# Altium schematic sheet LoRa_XCVR.SchDoc(LoRa_XCVR)
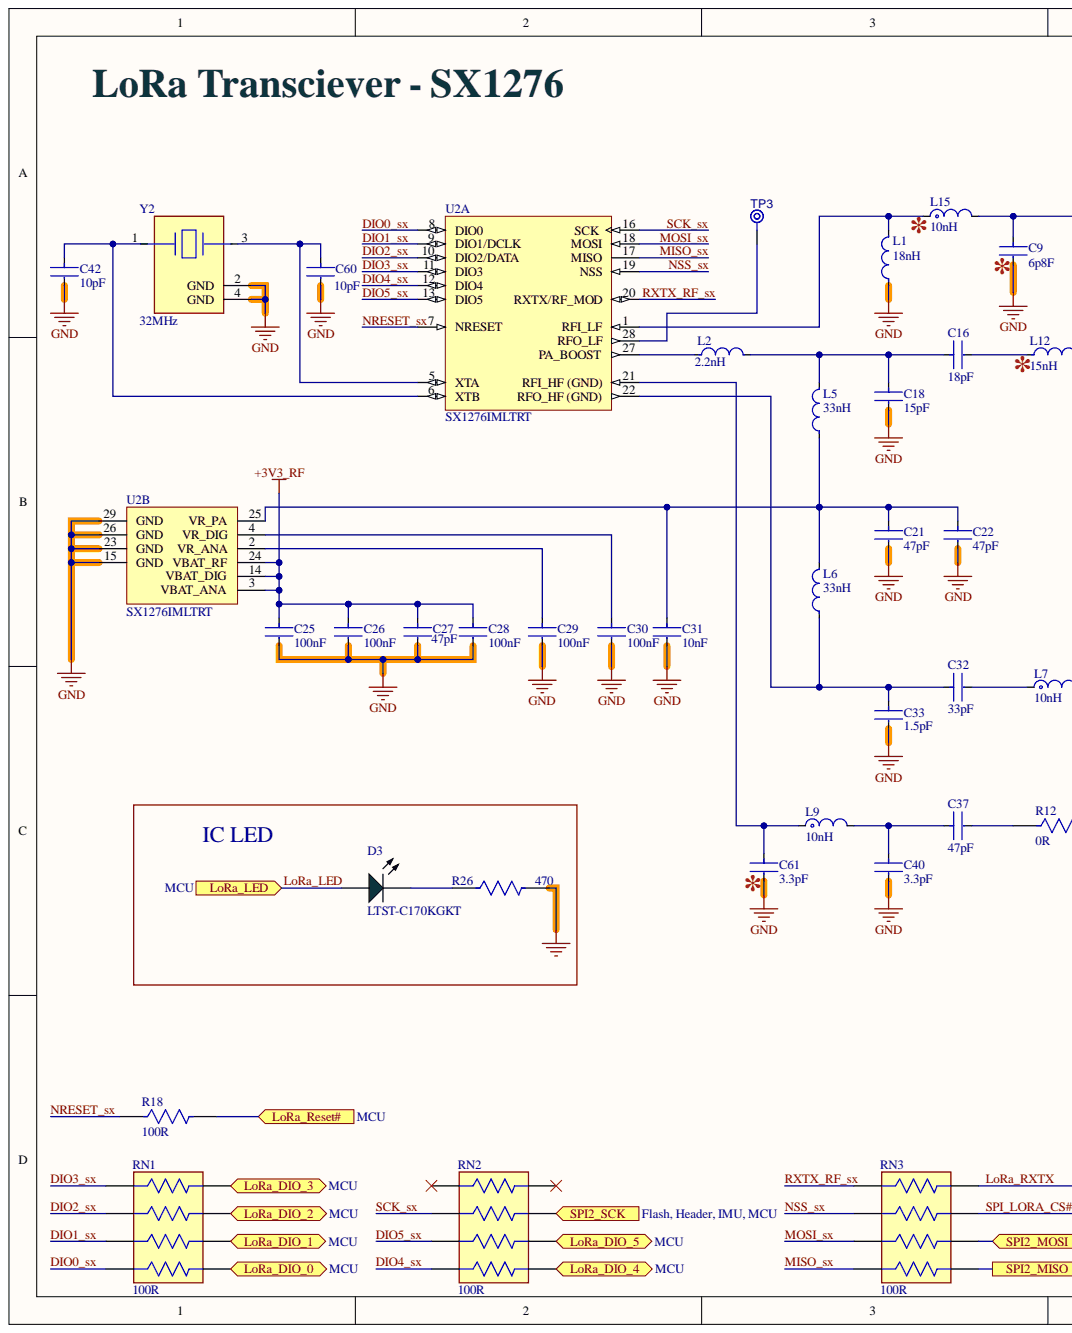
[diagram p0: LoRa_XCVR.SchDoc, left]
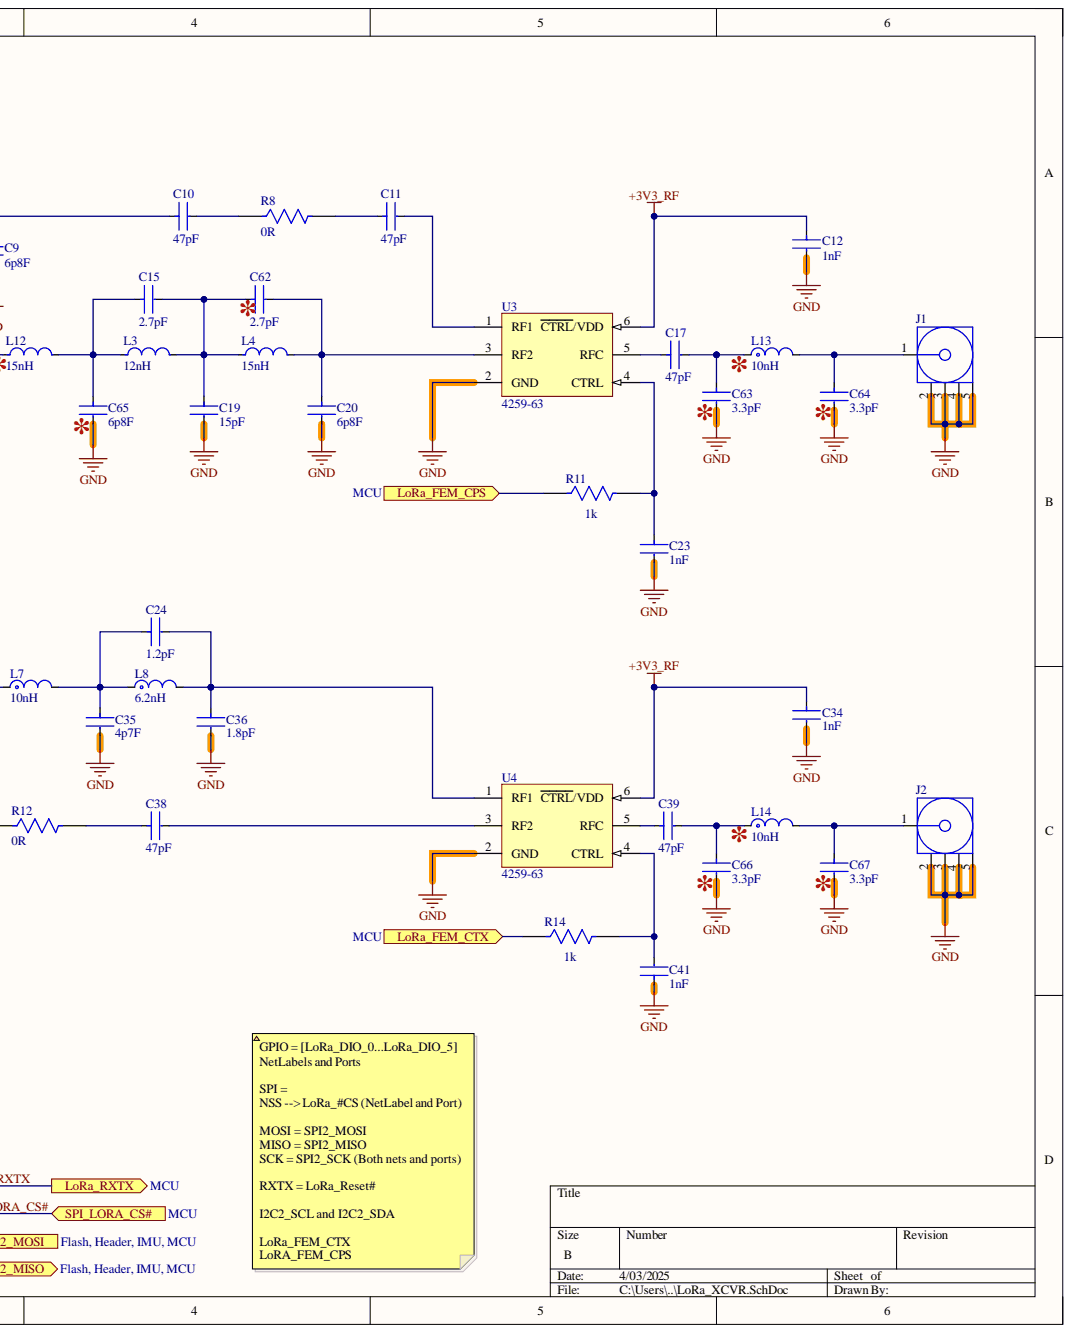
[diagram p1: LoRa_XCVR.SchDoc, right]

## Parts
C9  6p8F  [FP-GJM155-0_05-IPC_A]
C10  47pF  [FP-GJM155-0_05-MFG]
C11  47pF  [FP-GJM155-0_05-MFG]
C12  1nF  [FP-GRM155-0_05-IPC_C]
C15  2.7pF  [FP-GJM155-0_05-IPC_A]
C16  18pF  [FP-GJM155-0_05-IPC_B]
C17  47pF  [FP-GJM155-0_05-MFG]
C18  15pF  [FP-GJM155-0_05-IPC_A]
C19  15pF  [FP-GJM155-0_05-IPC_A]
C20  6p8F  [FP-GJM155-0_05-IPC_A]
C21  47pF  [FP-GJM155-0_05-MFG]
C22  47pF  [FP-GJM155-0_05-MFG]
C23  1nF  [FP-GRM155-0_05-IPC_C]
C24  1.2pF  [FP-GJM155-0_05-IPC_B]
C25  100nF  [FP-GRM155-0_05-IPC_B]
C26  100nF  [FP-GRM155-0_05-IPC_B]
C27  47pF  [FP-GJM155-0_05-MFG]
C28  100nF  [FP-GRM155-0_05-IPC_B]
C29  100nF  [FP-GRM155-0_05-IPC_B]
C30  100nF  [FP-GRM155-0_05-IPC_B]
C31  10nF  [FP-GRM155-0_05-IPC_C]
C32  33pF  [FP-GJM155-0_05-MFG]
C33  1.5pF  [FP-GJM155-0_05-IPC_C]
C34  1nF  [FP-GRM155-0_05-IPC_C]
C35  4p7F  [FP-GJM155-0_05-IPC_B]
C36  1.8pF  [FP-GJM155-0_05-IPC_B]
C37  47pF  [FP-GJM155-0_05-MFG]
C38  47pF  [FP-GJM155-0_05-MFG]
C39  47pF  [FP-GJM155-0_05-MFG]
C40  3.3pF  [FP-GJM155-0_05-IPC_C]
C41  1nF  [FP-GRM155-0_05-IPC_C]
C42  10pF  [FP-GRT155-0_05-MFG]
C60  10pF  [FP-GRT155-0_05-MFG]
C61  3.3pF  [FP-GJM155-0_05-IPC_C]
C62  2.7pF  [FP-GJM155-0_05-IPC_A]
C63  3.3pF  [FP-GJM155-0_05-IPC_C]
C64  3.3pF  [FP-GJM155-0_05-IPC_C]
C65  6p8F  [FP-GJM155-0_05-IPC_A]
C66  3.3pF  [FP-GJM155-0_05-IPC_C]
C67  3.3pF  [FP-GJM155-0_05-IPC_C]
D3  LTST-C170KGKT  [LTST-LTST-C170KGKT-2_V]
J1  142-0701-801  [CINC-142-0701-801_V]  (value not drawn)
J2  142-0701-801  [CINC-142-0701-801_V]  (value not drawn)
L1  18nH  [FP-LQW15AN_00-W0_6-0_1-MFG]
L2  2.2nH  [FP-LQW15AN_00-W0_6-0_1-MFG]
L3  12nH  [FP-LQW15AN_00-W0_6-0_1-MFG]
L4  15nH  [FP-LQW15AN_00-W0_6-0_1-MFG]
L5  33nH  [FP-LQW15AN_00-W0_5-0_1-MFG]
L6  33nH  [FP-LQW15AN_00-W0_5-0_1-MFG]
L7  10nH  [FP-LQG15HH_02-IPC_A]
L8  6.2nH  [FP-LQG15HH_02-IPC_C]
L9  10nH  [FP-LQG15HH_02-IPC_A]
L12  15nH  [FP-LQW15AN_00-W0_6-0_1-MFG]
L13  10nH  [FP-LQG15HH_02-IPC_A]
L14  10nH  [FP-LQG15HH_02-IPC_A]
L15  10nH  [FP-LQG15HH_02-IPC_A]
R8  0R  [FP-CRCW0603-C-IPC_B]
R11  1k  [FP-CRCW0402-e3-MFG]
R12  0R  [FP-CRCW0603-HPe3-MFG]
R14  1k  [FP-CRCW0402-e3-MFG]
R18  100R  [FP-CRCW0402-e3-IPC_A]
R26  470  [FP-CPF0603-MFG]
RN1  100R  [FP-EXB38V-MFG]
RN2  100R  [FP-EXB38V-MFG]
RN3  100R  [FP-EXB38V-MFG]
TP3  TPAD6R  [TP06R]  (value not drawn)  (no BOM)
U2  SX1276IMLTRT  [SMTC-QFN-28_V]  (parts A,B)
U3  4259-63  [PERE-SC-70-6_M]
U4  4259-63  [PERE-SC-70-6_M]
Y2  32MHz  [FP-ABM11-MFG]

## Ports
LoRa_DIO_0, LoRa_DIO_1, LoRa_DIO_2, LoRa_DIO_3, LoRa_DIO_4, LoRa_DIO_5, LoRa_FEM_CPS, LoRa_FEM_CTX, LoRa_LED, LoRa_Reset#, LoRa_RXTX, SPI2_MISO, SPI2_MOSI, SPI2_SCK, SPI_LORA_CS#

## Nets
+3V3_RF: C12.1 C25.1 C26.1 C27.1 C28.1 C34.1 U2.3(VBAT_ANA) U2.14(VBAT_DIG) U2.24(VBAT_RF) U3.6(CTRL/VDD) U4.6(CTRL/VDD)
GND: C9.2 C12.2 C18.2 C19.2 C20.2 C21.2 C22.2 C23.2 C25.2 C26.2 C27.2 C28.2 C29.2 C30.2 C31.2 C33.2 C34.2 C35.2 C36.2 C40.2 C41.1 C42.2 C60.2 C61.2 C63.2 C64.2 C65.2 C66.2 C67.2 J1.2 J1.3 J1.4 J1.5 J2.2 J2.3 J2.4 J2.5 L1.1 R26.2 U2.15(GND) U2.23(GND) U2.26(GND) U2.29(GND) U3.2(GND) U4.2(GND) Y2.2(GND) Y2.4(GND)
DIO0_sx: RN1.4 U2.8(DIO0)
DIO1_sx: RN1.3 U2.9(DIO1/DCLK)
DIO2_sx: RN1.2 U2.10(DIO2/DATA)
DIO3_sx: RN1.1 U2.11(DIO3)
DIO4_sx: RN2.4 U2.12(DIO4)
DIO5_sx: RN2.3 U2.13(DIO5)
LoRa_DIO_0: RN1.5 ; port LoRa_DIO_0
LoRa_DIO_1: RN1.6 ; port LoRa_DIO_1
LoRa_DIO_2: RN1.7 ; port LoRa_DIO_2
LoRa_DIO_3: RN1.8 ; port LoRa_DIO_3
LoRa_DIO_4: RN2.5 ; port LoRa_DIO_4
LoRa_DIO_5: RN2.6 ; port LoRa_DIO_5
LoRa_FEM_CPS: R11.1 ; port LoRa_FEM_CPS
LoRa_FEM_CTX: R14.1 ; port LoRa_FEM_CTX
LoRa_LED: D3.2 ; port LoRa_LED
LoRa_Reset#: R18.2 ; port LoRa_Reset#
LoRa_RXTX: RN3.8 ; port LoRa_RXTX
MISO_sx: RN3.4 U2.17(MISO)
MOSI_sx: RN3.3 U2.18(MOSI)
NRESET_sx: R18.1 U2.7(NRESET)
NSS_sx: RN3.2 U2.19(NSS)
RXTX_RF_sx: RN3.1 U2.20(RXTX/RF_MOD)
SCK_sx: RN2.2 U2.16(SCK)
SPI2_MISO: RN3.5 ; port SPI2_MISO
SPI2_MOSI: RN3.6 ; port SPI2_MOSI
SPI2_SCK: RN2.7 ; port SPI2_SCK
SPI_LORA_CS#: RN3.7 ; port SPI_LORA_CS#
NetC9_1: C9.1 C10.1 L15.2
NetC10_2: C10.2 R8.1
NetC11_1: C11.1 R8.2
NetC11_2: C11.2 U3.1(RF1)
NetC15_1: C15.1 C65.1 L3.1 L12.2
NetC15_2: C15.2 C19.1 C62.1 L3.2 L4.1
NetC16_1: C16.1 C18.1 L2.2 L5.2
NetC16_2: C16.2 L12.1
NetC17_1: C17.1 U3.5(RFC)
NetC17_2: C17.2 C63.1 L13.1
NetC20_1: C20.1 C62.2 L4.2 U3.3(RF2)
NetC21_1: C21.1 C22.1 C31.1 L5.1 L6.2 U2.25(VR_PA)
NetC23_1: C23.1 R11.2 U3.4(CTRL)
NetC24_1: C24.1 C35.1 L7.2 L8.1
NetC24_2: C24.2 C36.1 L8.2 U4.1(RF1)
NetC29_1: C29.1 U2.2(VR_ANA)
NetC30_1: C30.1 U2.4(VR_DIG)
NetC32_1: C32.1 C33.1 L6.1 U2.22(RFO_HF_(GND))
NetC32_2: C32.2 L7.1
NetC37_1: C37.1 C40.1 L9.2
NetC37_2: C37.2 R12.1
NetC38_1: C38.1 R12.2
NetC38_2: C38.2 U4.3(RF2)
NetC39_1: C39.1 U4.5(RFC)
NetC39_2: C39.2 C66.1 L14.1
NetC41_2: C41.2 R14.2 U4.4(CTRL)
NetC42_1: C42.1 U2.6(XTB) Y2.1
NetC60_1: C60.1 U2.5(XTA) Y2.3
NetC61_1: C61.1 L9.1 U2.21(RFI_HF_(GND))
NetC64_1: C64.1 J1.1 L13.2
NetC67_1: C67.1 J2.1 L14.2
NetD3_1: D3.1 R26.1
NetL1_2: L1.2 L15.1 U2.1(RFI_LF)
NetL2_1: L2.1 U2.27(PA_BOOST)
NetTP3_1: TP3.1 U2.28(RFO_LF)

Not connected: RN2 1 8

## Library data of the parts
C9 C20 C65 = CMP-2008-03772-2: Multi-Layer Ceramic Capacitor 6.8pF C0G  ±0.1pF 0402 Paper T/R; Voltage Rating: 50V; Capacitance: 6.8pF; Case Code (Imperial): 0402; Case Code (Metric): 1005; Case/Package: 0402; Component Kind: Standard; Depth: 0.5mm; Dielectric: C0G; Height: 0.5mm; Lead Free: Lead Free; Length: 1mm; Library Name: Altium Content Vault; Max Operating Temperature: 125°C; Min Operating Temperature: -55°C; Mount: Surface Mount; Package Quantity: 10000; Packaging: Tape and Reel; Radiation Hardening: No; Termination: SMD/SMT; Thickness: 0.022inch; Voltage Rating (DC): 50V; Width: 0.02inch
C10 C11 C17 C21 C22 C27 C37-C39 = CMP-06035-013708-1: Multi-Layer Ceramic Capacitor 47pF C0G  ±5% 0402 Paper T/R; Voltage Rating (DC): 50V; Capacitance: 47pF; Component Kind: Standard; Dielectric: C0G; Length: 1mm; Library Name: Altium Content Vault; Thickness: 500um; Tolerance: 5%; Width: 500um
C12 C23 C34 C41 = CMP-1034-01633-3: Chip Multilayer Ceramic Capacitors for General Purpose, 0402, 1000pF, X7R, 15%, 10%, 100V; Capacitance: 1nF; Case Code (Imperial): 0402; Case Code (Metric): 1005; Case/Package: 0402; Component Kind: Standard; Depth: 0.5mm; Dielectric: X7R; Height: 0.5mm; Lead Free: Lead Free; Length: 1mm; Library Name: Altium Content Vault; Material: Ceramic; Max Operating Temperature: 125°C; Min Operating Temperature: -55°C; Mount: Surface Mount; Package Quantity: 10000; Packaging: Tape and Reel; Radiation Hardening: No; REACH SVHC: No SVHC; Termination: SMD/SMT; Thickness: 0.022inch; Tolerance: 10%; Voltage: 100V; Voltage Rating: 100V; Voltage Rating (DC): 100V; Width: 0.5mm
C15 C62 = CMP-2008-03840-2: Multi-Layer Ceramic Capacitor 2.7pF C0G  ±0.1pF 0402 Paper T/R; Temperature Coefficient: 30ppm/°C; Voltage: 50V; Capacitance: 2.7pF; Case Code (Imperial): 0402; Case Code (Metric): 1005; Case/Package: 0402; Component Kind: Standard; Depth: 0.5mm; Dielectric: C0G; Height: 0.5mm; Lead Free: Lead Free; Lead Pitch: 0.3mm; Length: 1mm; Library Name: Altium Content Vault; Max Operating Temperature: 125°C; Min Operating Temperature: -55°C; Mount: Surface Mount; Number of Pins: 2; Package Quantity: 10000; Packaging: Tape and Reel; Radiation Hardening: No; REACH SVHC: No SVHC; Termination: SMD/SMT; Thickness: 0.022inch; Voltage Rating: 50V; Voltage Rating (DC): 50V; Width: 0.02inch
C16 = CMP-2008-03807-2: Multi-Layer Ceramic Capacitor 18pF C0G  ±5% 0402 Paper T/R; Voltage: 50V; Capacitance: 18pF; Case Code (Imperial): 0402; Case Code (Metric): 1005; Case/Package: 0402; Component Kind: Standard; Depth: 0.5mm; Dielectric: C0G; Height: 0.5mm; Lead Free: Lead Free; Length: 1mm; Library Name: Altium Content Vault; Max Operating Temperature: 125°C; Min Operating Temperature: -55°C; Mount: Surface Mount; Package Quantity: 1; Packaging: Tape and Reel; Radiation Hardening: No; Termination: SMD/SMT; Thickness: 0.022inch; Tolerance: 5%; Voltage Rating: 50V; Voltage Rating (DC): 50V; Width: 0.02inch
C18 C19 = CMP-2008-03804-2: Multi-Layer Ceramic Capacitor 15pF C0G  ±5% 0402 Paper T/R; Temperature Coefficient: 30ppm/°C; Voltage: 50V; Capacitance: 15pF; Case Code (Imperial): 0402; Case Code (Metric): 1005; Case/Package: 0402; Component Kind: Standard; Depth: 0.5mm; Dielectric: C0G; Height: 0.5mm; Lead Free: Lead Free; Lead Pitch: 0.3mm; Length: 1mm; Library Name: Altium Content Vault; Max Operating Temperature: 125°C; Min Operating Temperature: -55°C; Mount: Surface Mount; Number of Pins: 2; Package Quantity: 1; Packaging: Tape and Reel; Radiation Hardening: No; REACH SVHC: No SVHC; Termination: SMD/SMT; Thickness: 0.022inch; Tolerance: 5%; Voltage Rating: 50V; Voltage Rating (DC): 50V; Width: 0.02inch
C24 = CMP-2008-03813-2: Multi-Layer Ceramic Capacitor 1.2pF C0G  ±0.1pF 0402 Paper T/R; Temperature Coefficient: 30ppm/°C; Voltage: 50V; Capacitance: 1.2pF; Case Code (Imperial): 0402; Case Code (Metric): 1005; Case/Package: 0402; Component Kind: Standard; Depth: 0.5mm; Dielectric: C0G; Height: 0.5mm; Lead Free: Lead Free; Lead Pitch: 0.3mm; Length: 1mm; Library Name: Altium Content Vault; Max Operating Temperature: 125°C; Min Operating Temperature: -55°C; Mount: Surface Mount; Number of Pins: 2; Package Quantity: 10000; Packaging: Tape and Reel; Radiation Hardening: No; REACH SVHC: No SVHC; Termination: SMD/SMT; Thickness: 0.022inch; Voltage Rating: 50V; Voltage Rating (DC): 50V; Weight: 2.3E-05oz; Width: 0.5mm
C25 C26 C28-C30 = CMP-2008-04639-2: Chip Multilayer Ceramic Capacitors for General Purpose, 0402, 0.10uF, X7R, 15%, 10%, 50V; Dissipation Factor: 0.025%; Capacitance: 100nF; Case Code (Imperial): 0402; Case Code (Metric): 1005; Case/Package: 0402; Component Kind: Standard; Depth: 0.5mm; Dielectric: X7R; Dielectric Material: Ceramic; Height: 0.5mm; Lead Free: Lead Free; Length: 1mm; Library Name: Altium Content Vault; Material: Ceramic; Max Operating Temperature: 125°C; Min Operating Temperature: -55°C; Mount: Surface Mount; Package Quantity: 10000; Packaging: Tape and Reel; REACH SVHC: No SVHC; Resistor Type: Ceramic; Termination: SMD/SMT; Thickness: 0.022inch; Tolerance: 10%; Voltage: 50V; Voltage Rating: 50V; Voltage Rating (DC): 50V; Weight: 0.000212oz; Width: 0.5mm
C31 = CMP-2008-04637-5: Chip Multilayer Ceramic Capacitors for General Purpose, 0402, 10000pF, X7R, 15%, 10%, 50V; Voltage: 25V; Capacitance: 10nF; Case Code (Imperial): 0402; Case Code (Metric): 1005; Case/Package: 0402; Component Kind: Standard; Depth: 0.5mm; Dielectric: X7R; Height: 0.5mm; Lead Free: Lead Free; Length: 1mm; Library Name: Altium Content Vault; Material: Ceramic; Max Operating Temperature: 125°C; Min Operating Temperature: -55°C; Mount: Surface Mount; Number of Pins: 2; Package Quantity: 1; Packaging: Tape and Reel; Radiation Hardening: No; REACH SVHC: No SVHC; Termination: SMD/SMT; Thickness: 0.022inch; Tolerance: 10%; Voltage Rating: 50V; Voltage Rating (DC): 50V; Width: 0.02inch
C32 = CMP-06035-057988-1: Multi-Layer Ceramic Capacitor 33pF C0G  ±5% 0402 Paper T/R; Voltage Rating (DC): 50V; Capacitance: 33pF; Component Kind: Standard; Dielectric: C0G; Length: 1mm; Library Name: Altium Content Vault; Thickness: 500um; Tolerance: 5%; Width: 500um
C33 = CMP-2008-03820-2: Multi-Layer Ceramic Capacitor 1.5pF C0G  ±0.1pF 0402 Paper T/R; Temperature Coefficient: 30ppm/°C; Voltage: 50V; Capacitance: 1.5pF; Case Code (Imperial): 0402; Case Code (Metric): 1005; Case/Package: 0402; Component Kind: Standard; Construction: Flat; Depth: 0.5mm; Dielectric: C0G; Height: 0.5mm; Lead Free: Lead Free; Lead Pitch: 0.3mm; Length: 1mm; Library Name: Altium Content Vault; Max Operating Temperature: 125°C; Min Operating Temperature: -55°C; Mount: Surface Mount; Number of Pins: 2; Package Quantity: 10000; Packaging: Tape and Reel; Radiation Hardening: No; REACH SVHC: No SVHC; Series: GJM; Termination: SMD/SMT; Thickness: 0.022inch; Voltage Rating: 50V; Voltage Rating (DC): 50V; Width: 0.5mm
C35 = CMP-2008-03859-2: Multi-Layer Ceramic Capacitor 4.7pF C0G  ±0.25pF 0402 Paper T/R; Temperature Coefficient: 30ppm/°C; Voltage: 50V; Capacitance: 4.7pF; Case Code (Imperial): 0402; Case Code (Metric): 1005; Case/Package: 0402; Component Kind: Standard; Depth: 0.5mm; Dielectric: C0G; Height: 0.5mm; Lead Free: Lead Free; Lead Pitch: 0.3mm; Length: 1mm; Library Name: Altium Content Vault; Max Operating Temperature: 125°C; Min Operating Temperature: -55°C; Mount: Surface Mount; Number of Pins: 2; Package Quantity: 10000; Packaging: Tape and Reel; Radiation Hardening: No; REACH SVHC: No SVHC; Termination: SMD/SMT; Thickness: 0.022inch; Voltage Rating: 50V; Voltage Rating (DC): 50V; Width: 0.02inch
C36 = CMP-2008-03825-2: Multi-Layer Ceramic Capacitor 1.8pF C0G  ±0.1pF 0402 Paper T/R; Voltage: 50V; Capacitance: 1.8pF; Case Code (Imperial): 0402; Case Code (Metric): 1005; Case/Package: 0402; Component Kind: Standard; Depth: 0.5mm; Dielectric: C0G; Height: 0.5mm; Lead Free: Lead Free; Lead Pitch: 0.3mm; Length: 1mm; Library Name: Altium Content Vault; Max Operating Temperature: 125°C; Min Operating Temperature: -55°C; Mount: Surface Mount; Number of Pins: 2; Package Quantity: 10000; Packaging: Tape and Reel; Radiation Hardening: No; REACH SVHC: No SVHC; Termination: SMD/SMT; Thickness: 0.022inch; Voltage Rating: 50V; Voltage Rating (DC): 50V; Width: 0.5mm
C40 C61 C63 C64 C66 C67 = CMP-2008-03846-2: Multi-Layer Ceramic Capacitor 3.3pF C0G  ±0.1pF 0402 Paper T/R; Voltage: 50V; Capacitance: 3.3pF; Case Code (Imperial): 0402; Case Code (Metric): 1005; Case/Package: 0402; Component Kind: Standard; Depth: 0.5mm; Dielectric: C0G; Height: 0.5mm; Lead Free: Lead Free; Lead Pitch: 0.3mm; Length: 1mm; Library Name: Altium Content Vault; Max Operating Temperature: 125°C; Min Operating Temperature: -55°C; Mount: Surface Mount; Number of Pins: 2; Package Quantity: 1; Packaging: Tape and Reel; Radiation Hardening: No; REACH SVHC: No SVHC; Termination: SMD/SMT; Thickness: 0.022inch; Voltage Rating: 50V; Voltage Rating (DC): 50V; Width: 0.5mm
C42 C60 = CMP-06035-051459-1: Multi-Layer Ceramic Capacitor 10pF 50V C0G ±5% 0402 Paper T/R; Component Kind: Standard; Library Name: Altium Content Vault
D3 = CMP-2000-06620-1: Ultra Bright AlInGaP Chip LED, 75 mW, -55 to 85 degC, 2-Pin SMD (0805), RoHS, Tape and Reel; Manufacturer: Lite-On; Chip Material: AlGaInP; DELETED: Compliant; Dominant Wave Length: 571nm; Emitting Color: YellowGreen; Forward Current: 30mA; Forward Voltage: 2.4V; High Brightness: Yes; Lum Intensity: 35mcd; Number of  Elements: 1; Peak Wavelength: 574nm; Power Dissipation: 75W; Power Dissipation Max: 75mW; Product Depth (mm): 1.25mm; Product Height (mm): 1.1mm; Product Length (mm): 2mm; Rad Hardened: No; Reverse Voltage: 5V; Shape Type: Rectangular; Test Current (It): 20mA; Type: Uni-Color; Viewing Angle: 130deg; Component Kind: Standard; Datasheet URL: http://optoelectronics.liteon.com/upload/download/DS22-2000-073/S_110_LTST-C170KGKT.pdf; Datasheet Version: Rev. G, 06/2005; Forward Current Max: 30mA; Lens Dimensions: 1.4x1.25x0.6mm; Library Name: Altium Content Vault; Luminous Intensity: 35mcd; Main Category: ChipLED; Manufacturer URL: http://www.us.liteon.com/; Mounting: SurfaceMount; Mounting Technology: SurfaceMount; Operating Temp Range: -55Cto85C; Operating Temperature Classification: Industrial; Package Description: 2-Pin Surface Mounted Device, Body 2 x 1.25 mm; Package Reference: LTST-C170KGKT-2; Package Type: ChipLed; Packaging: TapeandReel; Pin Count: 2
J1 J2 = CMP-2000-05490-1: SMA End Launch Jack Receptacle, 50 Ohm, 0 to 18 GHz, -65 to 165 degC, 5-Pin SMD, RoHS, Bulk; Manufacturer: EmersonConnectivitySolutions; Body Material: Brass; Body Style: Straight; Brand: Johnson/EmersonConnectivitySolutions; Connector Type: JackPCB; Gender: Jack(Female); Impedance: 50Ohms; Maximum Frequency: 18GHz; Component Kind: Standard; Contact Plating: Gold; Datasheet URL: https://belfuse.com/resources/Johnson/productinformation/pi-142-0701-801.pdf; Datasheet Version: 12/2014; Factory Pack Quantity: 5; Library Name: Altium Content Vault; Manufacturer URL: http://www.cinch.com/; Mounting Technology: SurfaceMount; Operating Temperature Range: -65Cto+165C; Orientation: Straight; Package Description: 5-Pin Surface Mount Device, Body 14.275 x 9.525 mm; Package Reference: 142-0701-801; Package URL: https://belfuse.com/resources/Johnson/drawings/dr-1420701801.pdf; Product: Connectors; Product Category: RFConnectors/CoaxialConnectors; RF Series: SMA; RoHS: Details; Series: SMA; Termination Style: Solder
L1 = CMP-06042-006916-1: Wire Wound RF Inductor 18nH ±5% 370mA 0.27Ω 0402 (1005); Core Material: Air; DC Resistance (DCR): 270mR; Q Factor: 25; Self Resonant Frequency: 4.5GHz; Shielding: Unshielded; Test Frequency: 100MHz; Case Code (Imperial): 0402; Case Code (Metric): 1005; Case/Package: 0402; Component Kind: Standard; Current Rating: 370mA; DC Current: 370mA; Depth: 0.7mm; Height: 0.5mm; Inductance: 18nH; Lead Free: Lead Free; Length: 1mm; Library Name: Altium Content Vault; Max DC Current: 370mA; Max Operating Temperature: 125°C; Military Standard: Not; Min Operating Temperature: -55°C; Mount: Surface Mount; Package Quantity: 10000; Packaging: Tape and Reel; Radiation Hardening: No; Resistor Type: Wirewound; Termination: SMD/SMT; Tolerance: 5%; Weight: 2.8E-05oz; Width: 0.02inch
L2 = CMP-06042-008786-1: Wire Wound RF Inductor 2.2nH ±0.2nH 1000mA 0.027Ω 0402 (1005); Core Material: Air; Current Rating: 1A; DC Resistance (DCR): 27mR; Q Factor: 25; Self Resonant Frequency: 14GHz; Shielding: Unshielded; Test Frequency: 100MHz; Case Code (Imperial): 0402; Case Code (Metric): 1005; Case/Package: 0402; Component Kind: Standard; DC Current: 1A; Depth: 0.7mm; Height: 0.5mm; Inductance: 2.2nH; Lead Free: Lead Free; Length: 1mm; Library Name: Altium Content Vault; Max DC Current: 1A; Max Operating Temperature: 125°C; Military Standard: Not; Min Operating Temperature: -55°C; Mount: Surface Mount; Number of Pins: 2; Package Quantity: 10000; Packaging: Tape and Reel; Radiation Hardening: No; REACH SVHC: No SVHC; Termination: SMD/SMT; Weight: 2.8E-05oz; Width: 0.5mm
L3 = CMP-06042-005424-1: Wire Wound RF Inductor 12nH ±5% 500mA 0.14Ω 0402 (1005); Core Material: Air; DC Resistance (DCR): 140mR; Q Factor: 30; Self Resonant Frequency: 5.5GHz; Shielding: Unshielded; Test Frequency: 100MHz; Case Code (Imperial): 0402; Case Code (Metric): 1005; Case/Package: 0402; Component Kind: Standard; Current Rating: 500mA; DC Current: 500mA; Depth: 0.7mm; Height: 0.02inch; Inductance: 12nH; Lead Free: Lead Free; Length: 1.1mm; Library Name: Altium Content Vault; Max DC Current: 500mA; Max Operating Temperature: 125°C; Military Standard: Not; Min Operating Temperature: -55°C; Mount: Surface Mount; Package Quantity: 10000; Packaging: Tape and Reel; Radiation Hardening: No; Resistor Type: Wirewound; Termination: SMD/SMT; Tolerance: 5%; Weight: 2.8E-05oz; Width: 0.5mm
L4 L12 = CMP-2000-06595-2: Wire Wound RF Inductor 15nH ±5% 460mA 0.16Ω 0402 (1005); Core Material: Air; DC Resistance (DCR): 160mR; Q Factor: 30; Self Resonant Frequency: 5GHz; Shielding: Unshielded; Test Frequency: 100MHz; Case Code (Imperial): 0402; Case Code (Metric): 1005; Case/Package: 0402; Component Kind: Standard; Current Rating: 460mA; DC Current: 460mA; Depth: 0.7mm; Height: 0.5mm; Inductance: 15nH; Lead Free: Lead Free; Length: 1mm; Library Name: Altium Content Vault; Max DC Current: 460mA; Max Operating Temperature: 125°C; Military Standard: Not; Min Operating Temperature: -55°C; Mount: Surface Mount; Package Quantity: 10000; Packaging: Tape and Reel; Radiation Hardening: No; Resistor Type: Wirewound; Termination: SMD/SMT; Tolerance: 5%; Weight: 2.8E-05oz; Width: 0.02inch
L5 L6 = CMP-06042-007456-1: Wire Wound RF Inductor 33nH ±5% 260mA 0.63Ω 0402 (1005); Core Material: Air; DC Resistance (DCR): 630mR; Q Factor: 25; Self Resonant Frequency: 3.2GHz; Shielding: Unshielded; Test Frequency: 100MHz; Case Code (Imperial): 0402; Case Code (Metric): 1005; Case/Package: 0402; Component Kind: Standard; Current Rating: 260mA; DC Current: 260mA; Depth: 0.6mm; Height: 0.5mm; Inductance: 33nH; Lead Free: Lead Free; Length: 1mm; Library Name: Altium Content Vault; Max DC Current: 260mA; Max Operating Temperature: 125°C; Military Standard: Not; Min Operating Temperature: -55°C; Mount: Surface Mount; Package Quantity: 10000; Packaging: Tape and Reel; Radiation Hardening: No; Resistor Type: Wirewound; Termination: SMD/SMT; Tolerance: 5%; Weight: 2.8E-05oz; Width: 0.5mm
L7 L9 L13-L15 = CMP-06042-014117-1: Multilayer type RF Inductor 10nH ±5% 0.26Ω 500mA 0402; Core Material: Air; Current Rating: 300mA; DC Resistance (DCR): 260mR; Q Factor: 8; Self Resonant Frequency: 3.4GHz; Shielding: Unshielded; Test Frequency: 100MHz; Case Code (Imperial): 0402; Case Code (Metric): 1005; Case/Package: 0402; Component Kind: Standard; DC Current: 300mA; Depth: 0.55mm; Height: 0.5mm; Height - Seated (Max): 0.022inch; Inductance: 10nH; Lead Free: Lead Free; Length: 0.039inch; Library Name: Altium Content Vault; Max DC Current: 300mA; Max Operating Temperature: 125°C; Military Standard: Not; Min Operating Temperature: -55°C; Mount: Surface Mount; Package Quantity: 10000; Packaging: Tape and Reel; Radiation Hardening: No; Termination: SMD/SMT; Tolerance: 5%; Width: 0.5mm
L8 = CMP-06042-008294-1: Multilayer type RF Inductor 6.2nH ±0.3nH 0.2Ω 600mA 0402; Core Material: Air; Current Rating: 300mA; DC Resistance (DCR): 220mR; Q Factor: 8; Self Resonant Frequency: 4.5GHz; Shielding: Unshielded; Test Frequency: 100MHz; Case Code (Imperial): 0402; Case Code (Metric): 1005; Case/Package: 0402; Component Kind: Standard; DC Current: 300mA; Depth: 0.55mm; Height: 0.5mm; Height - Seated (Max): 0.022inch; Inductance: 6.2nH; Lead Free: Lead Free; Length: 1mm; Library Name: Altium Content Vault; Max DC Current: 300mA; Max Operating Temperature: 125°C; Military Standard: Not; Min Operating Temperature: -55°C; Mount: Surface Mount; Package Quantity: 10000; Packaging: Tape and Reel; Radiation Hardening: No; Termination: SMD/SMT; Width: 0.02inch
R8 = CMP-02407-012292-2: RES 0 OHM JUMPER 1/10W 0603; Power Rating: 100mW; Resistance: 22R; Case/Package: Molded; Component Kind: Standard; Contact Plating: Tin; Lead Free: Lead Free; Length: 1.55mm; Library Name: Altium Content Vault; Max Operating Temperature: 155°C; Min Operating Temperature: -55°C; Mount: Surface Mount; Resistor Type: Thick Film; RoHS Compliant: Yes; Termination: Wraparound
R11 R14 = CMP-1011-00074-3: RES Thick Film, 1kΩ, 5%, 0.063W, 200ppm/°C, 0402; Max Power Dissipation: 63mW; Resistance: 1kR; Voltage Rating: 50V; Case Code (Imperial): 0402; Case Code (Metric): 1005; Case/Package: 0402; Component Kind: Standard; Composition: Thick Film; Contact Plating: Tin; Depth: 0.5mm; Features: Automotive AEC-Q200; Height: 0.016inch; Length: 1mm; Library Name: Altium Content Vault; Max Operating Temperature: 155°C; Military Standard: Not; Min Operating Temperature: -55°C; Mount: Surface Mount; Number of Pins: 2; Number of Terminations: 2; Package Quantity: 1; Packaging: Tape and Reel; Power Rating: 63mW; Radiation Hardening: No; Temperature Coefficient: 200ppm/°C; Termination: SMD/SMT; Tolerance: 5%; Weight: 2.3E-05oz; Width: 0.5mm; Working Voltage: 50V
R12 = CMP-2000-03817-2: RES Thick Film, 0Ω, , 0.33W, , 0603; Max Power Dissipation: 250mW; Resistance: 0mR; Temperature Coefficient: 0ppm/°C; Voltage Rating: 75V; Case Code (Imperial): 0603; Case Code (Metric): 1608; Case/Package: 0603; Component Kind: Standard; Composition: Thick Film; Contact Plating: Tin; Depth: 0.85mm; Features: Automotive AEC-Q200; Height: 0.45mm; Lead Free: Lead Free; Length: 1.6mm; Library Name: Altium Content Vault; Max Operating Temperature: 155°C; Military Standard: Not; Min Operating Temperature: -55°C; Mount: Surface Mount; Number of Pins: 2; Number of Terminations: 2; Package Quantity: 5000; Packaging: Tape and Reel; Power Rating: 250mW; Radiation Hardening: No; Termination: SMD/SMT; Voltage Rating (DC): 75V; Weight: 7.1E-05oz; Width: 0.033inch
R18 = CMP-2002-08129-2: RES Thick Film, 100Ω, 5%, 0.063W, 200ppm/°C, 0402; Max Power Dissipation: 63mW; Voltage Rating: 50V; Case Code (Imperial): 0402; Case Code (Metric): 1005; Case/Package: 0402; Component Kind: Standard; Composition: Thick Film; Contact Plating: Tin; Depth: 0.5mm; Features: Automotive AEC-Q200; Height: 0.016inch; Length: 1mm; Library Name: Altium Content Vault; Max Operating Temperature: 155°C; Military Standard: Not; Min Operating Temperature: -55°C; Mount: Surface Mount; Number of Terminations: 2; Package Quantity: 10000; Packaging: Tape and Reel; Power Rating: 63mW; Radiation Hardening: No; Resistance: 100R; Temperature Coefficient: 200ppm/°C; Termination: SMD/SMT; Tolerance: 5%; Weight: 2.3E-05oz; Width: 0.02inch; Working Voltage: 50V
R26 = CMP-03211-004765-1: RES SMD 470Ω 0.1% 1/16W 0603    CPF0603B470RE; Manufacturer: TE Connectivity; Power Rating: 0.063W; Temperature Coefficient: 25ppm/°C; Voltage Rating: 50V; Automotive: No; Category: Res; Component Kind: Standard; ComponentLink2Description: Datasheet; ComponentLink2URL: https://www.te.com/commerce/DocumentDelivery/DDEController?Action=showdoc&DocId=Data+Sheet%7F1773200%7FM%7Fpdf%7FEnglish%7FENG_DS_1773200_M.pdf%7F3-1676481-2; Composition: Thin Film; Device Class L1: Passive Components; Device Class L2: Resistors; Device Class L3: Chip SMD Resistors; Height: 0.55mm; Ipc Land Pattern Name: RESC15580X45; Lead Free: Yes; Library Name: Altium Content Vault; Manufacturer Part Number: CPF0603B470RE; Octopart: https://octopart.com/cpf0603b470re-te+connectivity-23376607; octopart_url: https://octopart.com/cpf0603b470re-te+connectivity-23376607; Package: CPF0603; packaging: 5k Reel; Resistance: 470Ω; RoHS: TRUE; Series: CPF; Temperature: -55°C to +155°C; Temperature Range High: +155°C; Temperature Range Low: -55°C; Tolerance: 0.1%; Value: 470Ω
RN1-RN3 = CMP-05421-000004-1: RES ARRAY 4 RES 100 OHM 1206; Circuit Type: Isolated; Number of Contacts: 8; Number of Elements: 4; Power Per Element: 62.5mW; Resistance Tolerance: 5%; Temperature Coefficient: 200ppm/°C; Voltage Rating: 50V; Voltage Rating (DC): 100V; Case Code (Imperial): 1206; Case Code (Metric): 3216; Case/Package: 1206; Component Kind: Standard; Contact Plating: Tin; Depth: 1.6mm; Height: 0.5mm; Lead Free: Lead Free; Length: 3.2mm; Library Name: Altium Content Vault; Max Operating Temperature: 125°C; Military Standard: Not; Min Operating Temperature: -55°C; Mount: Surface Mount; Number of Pins: 8; Number of Resistors: 4; Number of Terminals/Pins: 8; Package Quantity: 1; Packaging: Tape and Reel; Radiation Hardening: No; REACH SVHC: No SVHC; Resistance: 100R; RoHS Compliant: Yes; Series: EXB; Technology: THICK FILM; Terminal Pitch: 0.8mm; Termination: Convex; Tolerance: 5%; Width: 0.063inch
TP3 = CMP-00028-00002-2: 0.6mm round; Pins: 1; Library Name: Personal Repository
U2 = CMP-2000-05356-1: Low Power Long Range Transceiver, 137 to 1020 MHz, -40 to 85 degC, 28-Pin QFN, RoHS, Tape and Reel; Manufacturer: Semtech; Current - Receiving: 10.8mA~12mA; Current - Transmitting: 20mA~120mA; Data Rate (Max): 300kbps; Design Resources: SX127xReferenceDesignOverviewDevelopmentToolSelector; Featured Product: SX1276LongRangeTransceiver; Frequency: 137MHz~1.02GHz; GPIO: 6; Mfg Application Notes: LoRaModulation:CrystalOscillatorGuidanceSX12xxSoftwareImplementationofDataWhiteningandCRCSX127xLoRaLowEnergyDesignGuideSX127xLoRaModemDesignersGuide; Modulation: FSK,GFSK,GMSK,MSK,OOK; Online Catalog: SX1276,SX1277andSX1278; Other Names: SX1276IMLTRTTR; PCN Design/Specification: CopperWire25/Mar/2014; Power - Output: 20dBm; Protocol: LoRa™; Sensitivity: -148dBm; Serial Interfaces: SPI; Voltage - Supply: 1.8V~3.7V; Category: RF/IFandRFID; Component Kind: Standard; Datasheet URL: http://www.semtech.com/images/datasheet/sx1276.pdf; Datasheet Version: Rev. 4, 03/2015; Family: RFTransceiverICs; Library Name: Altium Content Vault; Manufacturer URL: http://www.semtech.com/; Memory Size: -; Operating Temperature: -40degC~85degC; Package / Case: 28-VQFNExposedPad; Package Description: 28-Pin Quad Flat No-lead Package, Body 6 x 6 mm, Pitch 0.65 mm; Package Reference: QFN-28; Packaging: Tape&Reel(TR); RF Family/Standard: 802.15.4; Series: -; Standard Package: 3,000; Type: TxRx+MCU
U3 U4 = CMP-2000-05298-1: IC RF Switch SPDT, 50 Ohm, 6-Pin SC70, RoHS, Tape and Reel; Manufacturer: Peregrine Semiconductor; Frequency - Lower: 10MHz; Frequency - Upper: 3GHz; IIP3: 55dBm(typ); Impedance: 50Ohm; Insertion Loss @ Frequency: 0.5dB@2GHz; Isolation @ Frequency: 20dB@2GHz(typ); Online Catalog: PE4259; Other Names: 1046-1011-24259-63-ND425963PE4259PE4259SCBC-ZPE4259SCBC-Z-ND; P1dB: 29dBm(typ)IP1dB; RF Type: GeneralPurpose; Topology: Reflective; Voltage - Supply: 1.8V~3.3V; Category: RF/IFandRFID; Circuit: SPDT; Component Kind: Standard; Datasheet URL: https://datasheet.ciiva.com/15100/getdatasheetpartid-685660-15100501.pdf; Datasheet Version: Jan-11; Family: RFSwitches; Features: Single/DualLineControl; Library Name: Altium Content Vault; Manufacturer URL: http://www.psemi.com/; Operating Temperature: -40degC~85degC; Package / Case: 6-TSSOP,SC-88,SOT-363; Package Description: 6-Pin Small Outline Transistor, Body 2.05 x 1.25 mm, Pitch 0.65 mm; Package Reference: SC-70-6; Packaging: Tape&Reel(TR); Series: UltraCMOS; Standard Package: 3,000; Supplier Device Package: SC-70-6
Y2 = CMP-27762-009665-1: Crystal 32MHz ±10ppm 10pF SMD-4 2mm x 1.6mm; ESR (Equivalent Series Resistance): 80R; Case/Package: SMD/SMT; Component Kind: Standard; Frequency: 32MHz; Frequency Stability: 10ppm; Frequency Tolerance: 10ppm; Library Name: Altium Content Vault; Load Capacitance: 10pF; Max Operating Temperature: 70°C; Min Operating Temperature: -20°C; Mount: Surface Mount; Packaging: Tape and Reel
